# Altium schematic sheet Copy of MCU_32.SchDoc
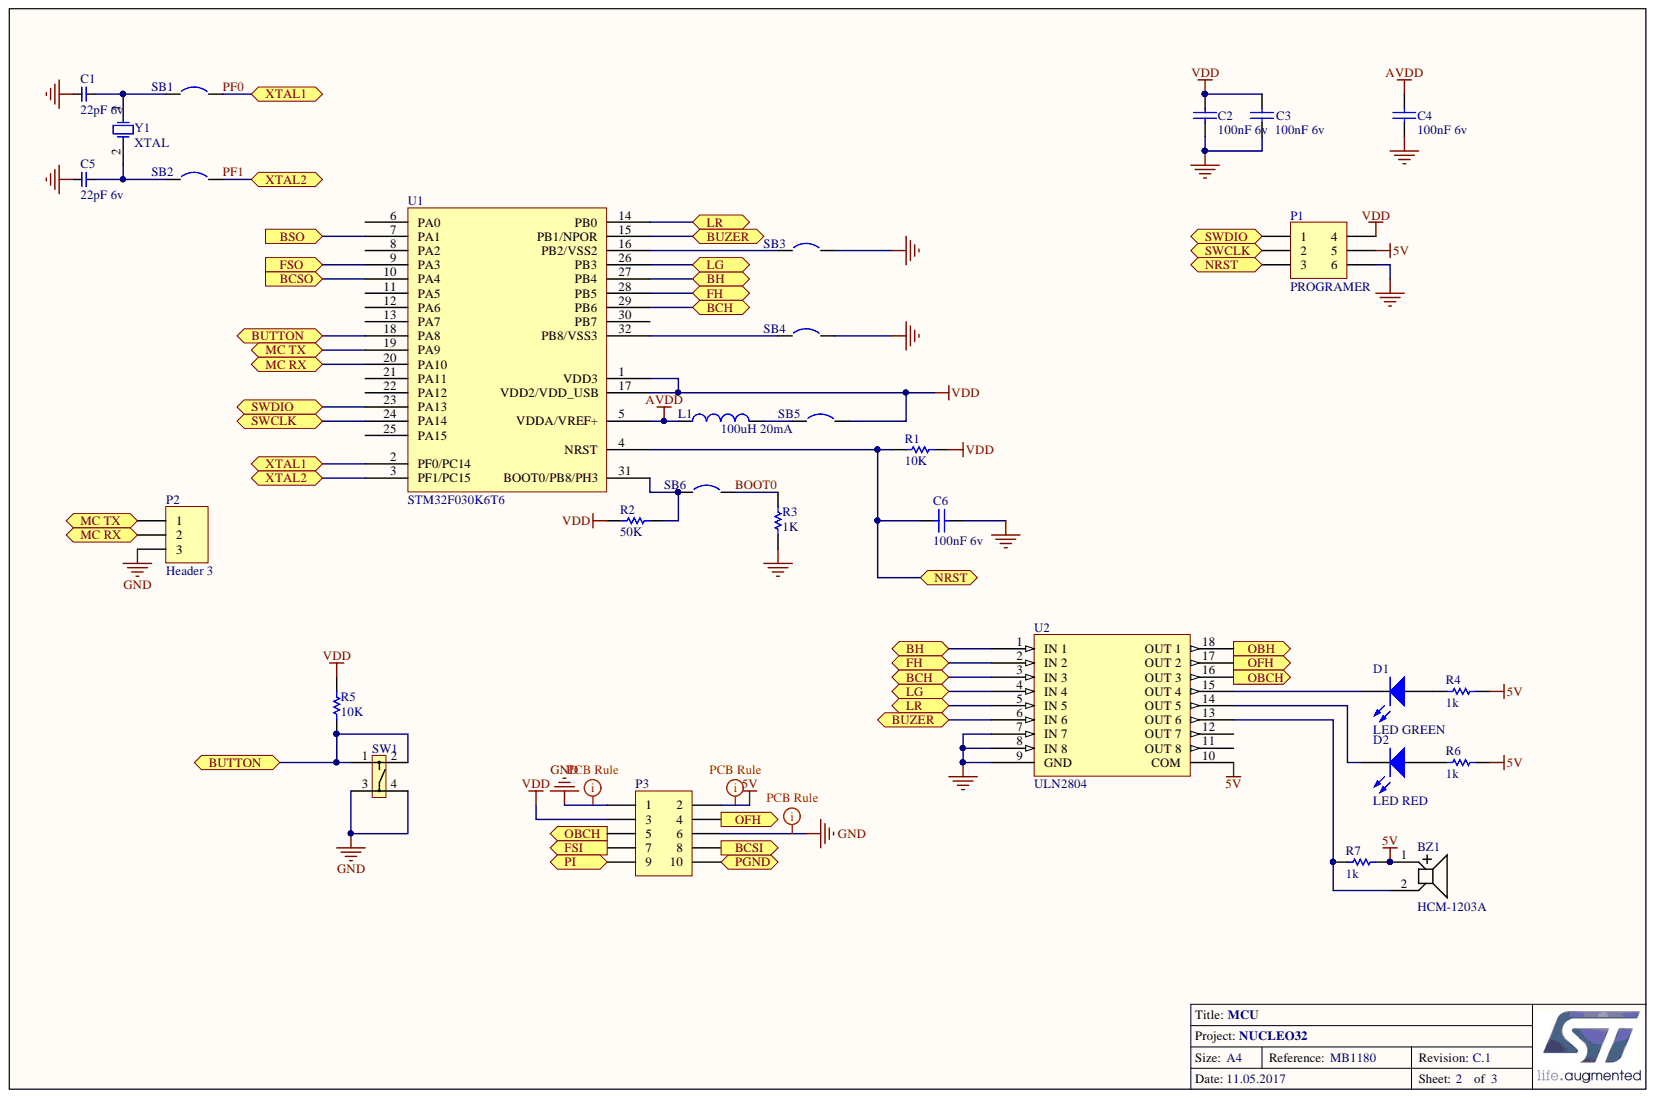
[diagram p0: Copy of MCU_32.SchDoc]

## Parts
BZ1  HCM-1203A  [HCM-1203A]
C1  22pF 6v  [CAP0603]
C2  100nF 6v  [0603C]
C3  100nF 6v  [0603C]
C4  100nF 6v  [0603C]
C5  22pF 6v  [CAP0603]
C6  100nF 6v  [0603C]
D1  LED GREEN  [My Green Led]
D2  LED RED  [My_LED3MM]
L1  100uH 20mA  value 100uH  [0603L]
P1  PROGRAMER  [HDR2X3_CEN]
P2  Header 3  [HDR1X3]
P3  Header 5X2  [HDR2X5]  (value not drawn)
R1  10K  [0603R]
R2  50K  [0603R]
R3  1K  [0603R]
R4  1k  [RES 1206/3216]
R5  10K  [0603R]
R6  1k  [RES 1206/3216]
R7  1k  [RES 1206/3216]
SB1  Circuit Breaker  [SB_0603]  (value not drawn)
SB2  Circuit Breaker  [SB_0603]  (value not drawn)
SB3  Circuit Breaker  [SB_0603]  (value not drawn)
SB4  Circuit Breaker  [SB_0603]  (value not drawn)
SB5  Circuit Breaker  [SB_0603]  (value not drawn)
SB6  Circuit Breaker  [SB_0603]  (value not drawn)
SW1  Button  [SMD_SWITCH]  (value not drawn)
U1  STM32F030K6T6  [LQFP32_0R5_7x7]
U2  ULN2804  [SOL-18_N]
Y1  XTAL  [3SMXA]

## Ports
BCH, BCSI, BCSO, BH, BSO, BUTTON, BUZER, FH, FSI, FSO, LG, LR, MC RX, MC TX, NRST, OBCH, OBH, OFH, PGND, PI, SWCLK, SWDIO, XTAL1, XTAL2

## Nets
5V: BZ1.1 P1.5 P3.2 R4.2 R6.2 R7.1 U2.10(COM)
AVDD: C4.1 L1.1 U1.5(VDDA/VREF+)
GND: C1.2 C2.2 C3.2 C4.2 C5.2 C6.1 P1.6 P2.3 P3.1 P3.6 R3.2 SB3.2 SB4.2 SW1.3 SW1.4 U2.7(IN_7) U2.8(IN_8) U2.9(GND)
VDD: C2.1 C3.1 P1.4 P3.3 R1.1 R2.2 R5.1 SB5.2 U1.1(VDD3) U1.17(VDD2/VDD_USB)
BCH: U1.29(PB6) U2.3(IN_3) ; port BCH
BCSI: P3.8 ; port BCSI
BCSO: U1.10(PA4) ; port BCSO
BH: U1.27(PB4) U2.1(IN_1) ; port BH
BOOT0: R3.1 SB6.2
BSO: U1.7(PA1) ; port BSO
BUTTON: R5.2 SW1.1 SW1.2 U1.18(PA8) ; port BUTTON
BUZER: U1.15(PB1/NPOR) U2.6(IN_6) ; port BUZER
FH: U1.28(PB5) U2.2(IN_2) ; port FH
FSI: P3.7 ; port FSI
FSO: U1.9(PA3) ; port FSO
LG: U1.26(PB3) U2.4(IN_4) ; port LG
LR: U1.14(PB0) U2.5(IN_5) ; port LR
MC RX: P2.2 U1.20(PA10) ; port MC RX
MC TX: P2.1 U1.19(PA9) ; port MC TX
NRST: C6.2 P1.3 R1.2 U1.4(NRST) ; port NRST
OBCH: P3.5 U2.16(OUT_3) ; port OBCH
OBH: U2.18(OUT_1) ; port OBH
OFH: P3.4 U2.17(OUT_2) ; port OFH
PF0: SB1.2 U1.2(PF0/PC14) ; port XTAL1
PF1: SB2.2 U1.3(PF1/PC15) ; port XTAL2
PGND: P3.10 ; port PGND
PI: P3.9 ; port PI
SWCLK: P1.2 U1.24(PA14) ; port SWCLK
SWDIO: P1.1 U1.23(PA13) ; port SWDIO
NetBZ1_2: BZ1.2 R7.2 U2.13(OUT_6)
NetC1_1: C1.1 SB1.1 Y1.1
NetC5_1: C5.1 SB2.1 Y1.2
NetD1_A: D1.A R4.1
NetD1_K: D1.K U2.15(OUT_4)
NetD2_A: D2.A R6.1
NetD2_K: D2.K U2.14(OUT_5)
NetL1_2: L1.2 SB5.1
NetR2_1: R2.1 SB6.1 U1.31(BOOT0/PB8/PH3)
NetSB3_1: SB3.1 U1.16(PB2/VSS2)
NetSB4_1: SB4.1 U1.32(PB8/VSS3)

Not connected: U1 6(PA0) 8(PA2) 11(PA5) 12(PA6) 13(PA7) 21(PA11) 22(PA12) 25(PA15) 30(PB7); U2 11(OUT_8) 12(OUT_7)

## Library data of the parts
BZ1 = HCM-1203A: HCM-1203A buzzer; Component Kind: Standard; Library Name: Misc.SchLib
C1 C5 = Capacitor_np: Value: 22pF 5v; Component Kind: Standard; Description: 22pF 6v; Library Name: PassiveSMT.schlib
C2 = Cap: Capacitor 100nF 5v; Value: 10nF; Component Kind: Standard; Library Name: Miscellaneous Devices.IntLib; Published: 8-Jun-2000; Revision: July-2002: Re-released for DXP Platform.; Signal Integrity: Cap; Simulation: CAP; Publisher: Altium Limited
C3 C4 = Cap: Capacitor; Value: 10nF; Component Kind: Standard; Library Name: Miscellaneous Devices.IntLib; Published: 8-Jun-2000; Revision: July-2002: Re-released for DXP Platform.; Signal Integrity: Cap; Simulation: CAP; Publisher: Altium Limited
C6 = CAP: Capacitor; Value: 100pF; Component Kind: Standard; Library Name: Miscellaneous Devices.IntLib; Published: 8-Jun-2000; Revision: July-2002: Re-released for DXP Platform.; Signal Integrity: Cap; Simulation: CAP; Publisher: Altium Limited
D1 D2 = LED3m: Typical INFRARED GaAs LED; Component Kind: Standard; LatestRevisionDate: 08-Jul-2005; LatestRevisionNote: Mech Layer 1 removed.; Library Name: Mylib.IntLib; Published: 8-Jun-2000; Publisher: Altium Limited
L1 = Inductor: Component Kind: Standard; Description: Inductor; Library Name: Miscellaneous Devices.IntLib; Published: 8-Jun-2000; Revision: July-2002: Re-released for DXP Platform.; Publisher: Altium Limited
P1 = Header 3X2A: Header, 3-Pin, Dual row; Component Kind: Standard; LatestRevisionDate: 17-Jul-2002; LatestRevisionNote: Re-released for DXP Platform.; Library Name: Miscellaneous Connectors.IntLib; Signal Integrity: Connector; Publisher: Altium Limited
P2 = Header 3: Header, 3-Pin; Component Kind: Standard; LatestRevisionDate: 17-Jul-2002; LatestRevisionNote: Re-released for DXP Platform.; Library Name: Miscellaneous Connectors.IntLib; Signal Integrity: Connector; Publisher: Altium Limited
P3 = Header 5X2: Header, 5-Pin, Dual row; Component Kind: Standard; LatestRevisionDate: 17-Jul-2002; LatestRevisionNote: Re-released for DXP Platform.; Library Name: Miscellaneous Connectors.IntLib; Signal Integrity: Connector; Publisher: Altium Limited
R1 R2 R5 = Res1: Resistor; Value: 1K; Component Kind: Standard; Library Name: Miscellaneous Devices.IntLib; Published: 8-Jun-2000; Revision: July-2002: Re-released for DXP Platform.; Signal Integrity: Res; Simulation: RESISTOR; Publisher: Altium Limited
R3 = res1: Resistor; Component Kind: Standard; Library Name: Miscellaneous Devices.IntLib; Published: 8-Jun-2000; Revision: July-2002: Re-released for DXP Platform.; Signal Integrity: Res; Simulation: RESISTOR; Value: 1K; Publisher: Altium Limited
R4 R6 R7 = Resistor1: Resistor; Component Kind: Standard; Library Name: PassiveSMT.schlib; Value: Value
SB1-SB6 = Circuit Breaker: Solder: NO; Component Kind: Standard; Description: Circuit Breaker; Library Name: MCD_TOOLS.SCHLIB; Published: 24-Mar-1999; Revision: July-2002: Re-released for DXP Platform.; Publisher: Altium Limited
SW1 = SMD_SWITCH: Component Kind: Standard; Library Name: Misc.SchLib
U1 = MCU_LQFP32: Component Kind: Standard; Library Name: MB1180.SCHLIB; Type: STM32F030K6T6
U2 = ULN2803: HIGH-VOLTAGE,HIGH-CURRENT DARLINTON ARRAYS; Component Kind: Standard; Library Name: PCB_Project1.SCHLIB
Y1 = XTAL: Crystal Oscillator 0.197" L x 0.126" W (5.00mm x 3.20mm); Component Kind: Standard; LatestRevisionDate: 17-Jul-2002; LatestRevisionNote: Re-released for DXP Platform.; Library Name: Miscellaneous Devices.SchLib; PackageDescription: Crystal, Thru-Hole; 2 Leads; Body 3.1 x 8.2 mm (Dia.xH); PackageReference: R38; Published: 8-Jun-2000; Simulation: XTAL; Publisher: Altium Limited
